# Altium schematic sheet Power_Regulation.SchDoc
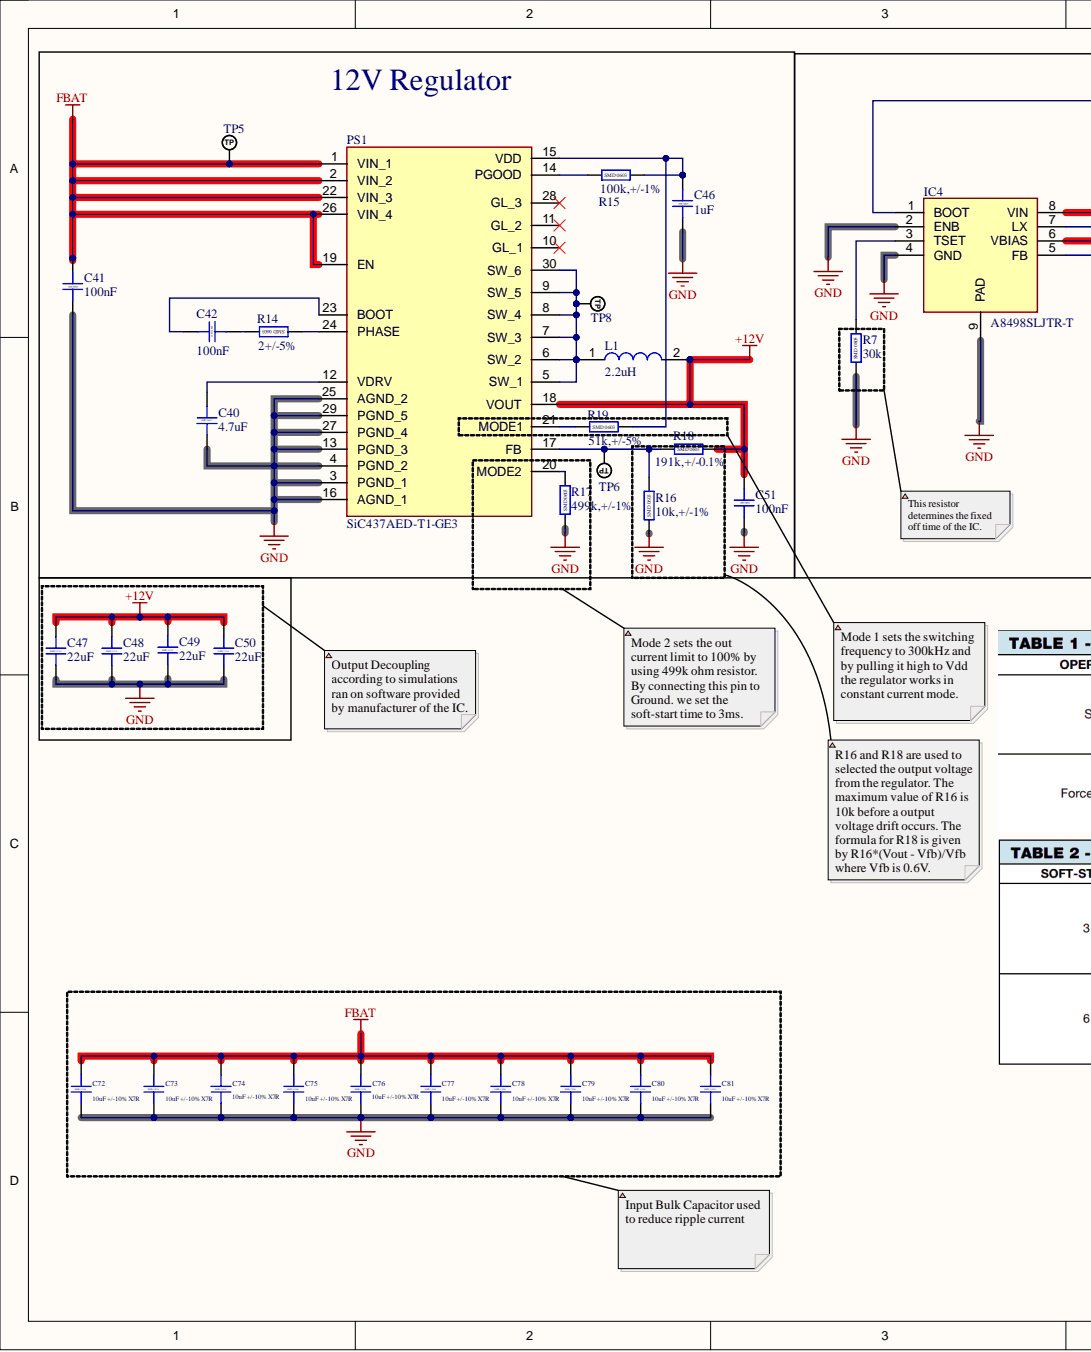
[diagram p0: Power_Regulation.SchDoc, left]
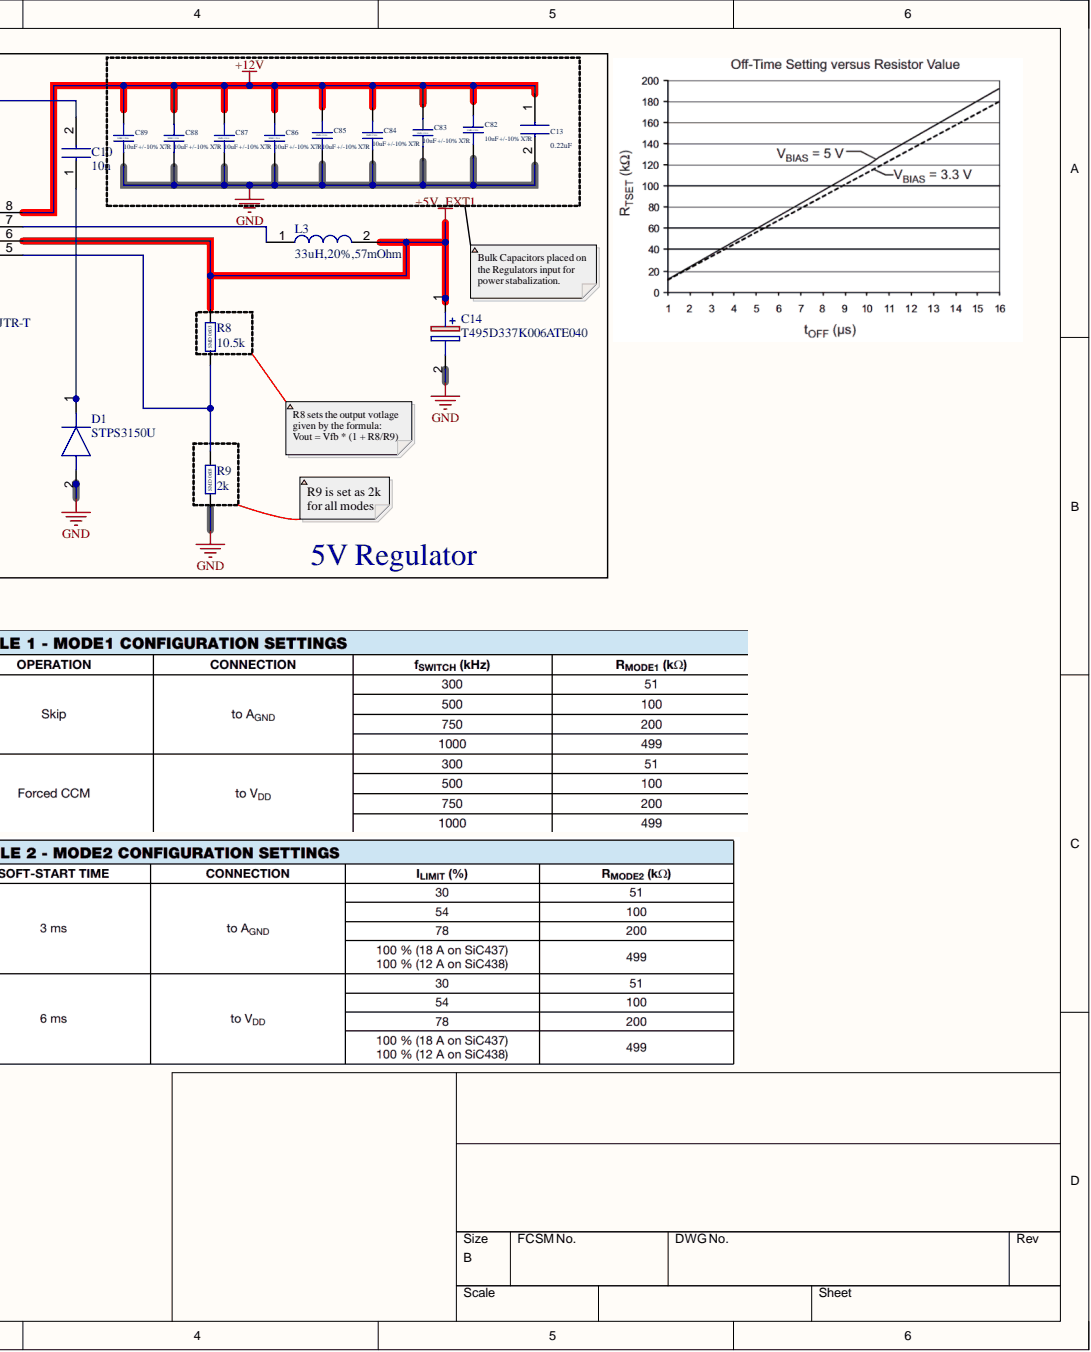
[diagram p1: Power_Regulation.SchDoc, right]

## Parts
C10  10n  [CAP_0603]
C13  0.22uF  [CAP_0805]
C14  T495D337K006ATE040  [T495D]
C40  4.7uF  [CAP_0603]
C41  100nF  [CAP_0603]
C42  100nF  [CAP_0603]
C46  1uF  [CAP_0603]
C47  22uF  [CAP_1210]
C48  22uF  [CAP_1210]
C49  22uF  [CAP_1210]
C50  22uF  [CAP_1210]
C51  100nF  [CAP_0603]
C72  10uF +/-10% X7R  [CAP_1206]
C73  10uF +/-10% X7R  [CAP_1206]
C74  10uF +/-10% X7R  [CAP_1206]
C75  10uF +/-10% X7R  [CAP_1206]
C76  10uF +/-10% X7R  [CAP_1206]
C77  10uF +/-10% X7R  [CAP_1206]
C78  10uF +/-10% X7R  [CAP_1206]
C79  10uF +/-10% X7R  [CAP_1206]
C80  10uF +/-10% X7R  [CAP_1206]
C81  10uF +/-10% X7R  [CAP_1206]
C82  10uF +/-10% X7R  [CAP_1206]
C83  10uF +/-10% X7R  [CAP_1206]
C84  10uF +/-10% X7R  [CAP_1206]
C85  10uF +/-10% X7R  [CAP_1206]
C86  10uF +/-10% X7R  [CAP_1206]
C87  10uF +/-10% X7R  [CAP_1206]
C88  10uF +/-10% X7R  [CAP_1206]
C89  10uF +/-10% X7R  [CAP_1206]
D1  STPS3150U  [SMBJ50CA]
IC4  A8498SLJTR-T  [SOIC127P600X175-8N]
L1  2.2uH  [SRP1265WA2R2M]
L3  33uH,20%,57mOhm  [INDPM134126X515N]
PS1  SiC437AED-T1-GE3  [SIC437DEDT1GE3]
R7  30k  [RES_0805]
R8  10.5k  [RES_0603]
R9  2k  [RES_0603]
R14  2+/-5%  [RES_0603]
R15  100k,+/-1%  [RES_0603]
R16  10k,+/-1%  [RES_0603]
R17  499k,+/-1%  [RES_0603]
R18  191k,+/-0.1%  [RES_0603]
R19  51k,+/-5%  [RES_0603]
TP5  TP_NOBOM  [PAD_RND_1MM]  (value not drawn)  (no BOM)
TP6  TP_NOBOM  [PAD_RND_1MM]  (value not drawn)  (no BOM)
TP8  TP_NOBOM  [PAD_RND_1MM]  (value not drawn)  (no BOM)

## Nets
+5V_EXT1: C14.1 IC4.6(VBIAS) L3.2 R8.2
+12V: C13.1 C47.1 C48.1 C49.1 C50.1 C51.2 C82.1 C83.1 C84.1 C85.1 C86.1 C87.1 C88.1 C89.1 IC4.8(VIN) L1.2 PS1.18(VOUT) R18.2
FBAT: C41.2 C72.1 C73.1 C74.1 C75.1 C76.1 C77.1 C78.1 C79.1 C80.1 C81.1 PS1.1(VIN_1) PS1.2(VIN_2) PS1.19(EN) PS1.22(VIN_3) PS1.26(VIN_4) TP5.1
GND: C13.2 C14.2 C40.2 C41.1 C46.1 C47.2 C48.2 C49.2 C50.2 C51.1 C72.2 C73.2 C74.2 C75.2 C76.2 C77.2 C78.2 C79.2 C80.2 C81.2 C82.2 C83.2 C84.2 C85.2 C86.2 C87.2 C88.2 C89.2 D1.2 IC4.2(ENB) IC4.4(GND) IC4.9(PAD) PS1.3(PGND_1) PS1.4(PGND_2) PS1.13(PGND_3) PS1.16(AGND_1) PS1.25(AGND_2) PS1.27(PGND_4) PS1.29(PGND_5) R7.1 R9.1 R16.1 R17.1
NetC10_1: C10.1 D1.1
NetC10_2: C10.2 IC4.1(BOOT)
NetC40_1: C40.1 PS1.12(VDRV)
NetC42_1: C42.1 R14.2
NetC42_2: C42.2 PS1.23(BOOT)
NetC46_2: C46.2 PS1.15(VDD) R15.2 R19.2
NetIC4_3: IC4.3(TSET) R7.2
NetIC4_5: IC4.5(FB) R8.1 R9.2
NetIC4_7: IC4.7(LX) L3.1
NetL1_1: L1.1 PS1.5(SW_1) PS1.6(SW_2) PS1.7(SW_3) PS1.8(SW_4) PS1.9(SW_5) PS1.30(SW_6) TP8.1
NetPS1_14: PS1.14(PGOOD) R15.1
NetPS1_17: PS1.17(FB) R16.2 R18.1 TP6.1
NetPS1_20: PS1.20(MODE2) R17.2
NetPS1_21: PS1.21(MODE1) R19.1
NetPS1_24: PS1.24(PHASE) R14.1

Not connected: PS1 10(GL_1) 11(GL_2) 28(GL_3)

## Library data of the parts
C10 = C1608X7R1H103K080AA: Capacitor; Manufacturer_Name: TDK; Arrow Part Number: C1608X7R1H103K080AA; Arrow Price/Stock: https://www.arrow.com/en/products/c1608x7r1h103k080aa/tdk?utm_currency=USD&region=europe; Component Kind: Standard; Datasheet Link: https://product.tdk.com/info/en/catalog/datasheets/mlcc_commercial_midvoltage_en.pdf; Height: 0.8mm; Manufacturer_Part_Number: C1608X7R1H103K080AA; Mouser Part Number: 810-C1608X7R1H103K; Mouser Price/Stock: https://www.mouser.co.uk/ProductDetail/TDK/C1608X7R1H103K080AA?qs=NRhsANhppD90z4jf8QJnTg%3D%3D
C13 = 08055C104JAT2A: Capacitor; Manufacturer_Name: TDK; Component Kind: Standard; Datasheet Link: https://datasheets.kyocera-avx.com/X7RDielectric.pdf; Height: 0.94mm; Manufacturer_Part_Number: 08055C104JAT2A
C14 = T495D337K006ATE040: Capacitor Polarised; Manufacturer_Name: KEMET; Component Kind: Standard; Datasheet Link: https://content.kemet.com/datasheets/KEM_T2009_T495.pdf; Height: 3.1mm; Library Name: SamacSys.SchLib; Manufacturer_Part_Number: T495D337K006ATE040
C40 = Capacitor-SMD-0603: GRM188R6YA475KE15D; Component Kind: Standard; Library Name: STeRG-Passives-Standard.SchLib
C41 C42 C46 C51 = Capacitor-SMD-0603: C0603C104K3RACTU; Component Kind: Standard; Library Name: STeRG-Passives-Standard.SchLib
C47-C50 = Capacitor-SMD-1210: CL32A226KAJNNNE; Component Kind: Standard; Library Name: STeRG-Passives-Standard.SchLib
C72-C89 = Capacitor-SMD-1206: Capacitor SMD 1206 RATED; Component Kind: Standard; Library Name: STeRG-Passives-Standard.SchLib
D1 = STPS3150U: Diode; Manufacturer_Name: STMicroelectronics; Arrow Part Number: STPS3150U; Arrow Price/Stock: https://www.arrow.com/en/products/stps3150u/stmicroelectronics?utm_currency=USD&region=europe; Component Kind: Standard; Datasheet Link: https://www.st.com/resource/en/datasheet/stps3150.pdf; Height: 2.65mm; Library Name: SamacSys.SchLib; Manufacturer_Part_Number: STPS3150U; Mouser Part Number: 511-STPS3150U; Mouser Price/Stock: https://www.mouser.co.uk/ProductDetail/STMicroelectronics/STPS3150U?qs=sepekKm5O7mdIVKQ3I2tDA%3D%3D
IC4 = A8498SLJTR-T: Integrated Circuit; Manufacturer_Name: Allegro Microsystems; Arrow Part Number: A8498SLJTR-T; Arrow Price/Stock: https://www.arrow.com/en/products/a8498sljtr-t/allegro-microsystems?region=nac; Component Kind: Standard; Datasheet Link: http://www.allegromicro.com/~/media/files/datasheets/a8498-datasheet.ashx; Height: 1.7mm; Manufacturer_Part_Number: A8498SLJTR-T; Mouser Part Number: 250-A8498SLJTR-T; Mouser Price/Stock: https://www.mouser.co.uk/ProductDetail/Allegro-MicroSystems/A8498SLJTR-T?qs=pUKx8fyJudCw1xpOohcA8A%3D%3D
L1 = SRP1265WA-2R2M: Inductor; Manufacturer_Name: Bourns; Arrow Part Number: SRP1265WA-2R2M; Arrow Price/Stock: https://www.arrow.com/en/products/srp1265wa-2r2m/bourns?utm_currency=USD&region=nac; Component Kind: Standard; Datasheet Link: http://www.bourns.com/docs/Product-Datasheets/SRP1265WA.pdf; Height: 6.5mm; Library Name: SamacSys.SchLib; Manufacturer_Part_Number: SRP1265WA-2R2M; Mouser Part Number: 652-SRP1265WA-2R2M; Mouser Price/Stock: https://www.mouser.co.uk/ProductDetail/Bourns/SRP1265WA-2R2M?qs=Li%252BoUPsLEnvRcUJ534J08A%3D%3D
L3 = 125CDMCCDS-330MC: Inductor; Manufacturer_Name: Sumida; Arrow Part Number: 125CDMCCDS-330MC; Arrow Price/Stock: https://www.arrow.com/en/products/125cdmccds-330mc/sumida?region=nac; Component Kind: Standard; Datasheet Link: https://products.sumida.com/products/pdf/125CDMCCDS%20(en).pdf; Height: 5.15mm; Library Name: SamacSys.SchLib; Manufacturer_Part_Number: 125CDMCCDS-330MC; Mouser Part Number: 851-125CDMCCDS330MC; Mouser Price/Stock: https://www.mouser.co.uk/ProductDetail/Sumida/125CDMCCDS-330MC?qs=%252BEew9%252B0nqrCW2oHfLcKqBw%3D%3D
PS1 = SiC437AED-T1-GE3: Integrated Circuit; Manufacturer_Name: Vishay; Arrow Part Number: SIC437AED-T1-GE3; Arrow Price/Stock: https://www.arrow.com/en/products/sic437aed-t1-ge3/vishay?utm_currency=USD&region=nac; Component Kind: Standard; Datasheet Link: https://www.mouser.fr/datasheet/2/427/sic437-1670527.pdf; Height: 0.8mm; Library Name: SamacSys.SchLib; Manufacturer_Part_Number: SiC437AED-T1-GE3; Mouser Part Number: 78-SIC437AED-T1-GE3; Mouser Price/Stock: https://www.mouser.co.uk/ProductDetail/Vishay-Siliconix/SIC437AED-T1-GE3?qs=chTDxNqvsyloQdgCHbSYaQ%3D%3D
R7 = Resistor-SMD-0805: Resistor SMD 0805 RATED; Component Kind: Standard; Library Name: STeRG-Passives-Standard.SchLib
R8 = Resistor-SMD-0603: RK73H1JTTD1052F; Component Kind: Standard; Library Name: STeRG-Passives-Standard.SchLib
R9 = Resistor-SMD-0603: CRCW06032K00FKEA; Component Kind: Standard; Library Name: STeRG-Passives-Standard.SchLib
R14 = Resistor-SMD-0603: MCSR06W2R00FTL; Component Kind: Standard; Library Name: STeRG-Passives-Standard.SchLib
R15 = Resistor-SMD-0603: CRCW0603100KFKEA; Component Kind: Standard; Library Name: STeRG-Passives-Standard.SchLib
R16 R18 = Resistor-SMD-0603: CRCW060310K0FKEA; Component Kind: Standard; Library Name: STeRG-Passives-Standard.SchLib
R17 = Resistor-SMD-0603: CRCW0603499KFKEAC; Component Kind: Standard; Library Name: STeRG-Passives-Standard.SchLib
R19 = Resistor-SMD-0603: CR0603-JW-513ELF; Component Kind: Standard; Library Name: STeRG-Passives-Standard.SchLib
TP5 TP6 TP8 = TEST_POINT_RND_1MM: Test Point Round 1mm (NO BOM); Library Name: STeRG-Passives-Standard.SchLib
